# Altium schematic sheet SPI Extender 2.SchDoc
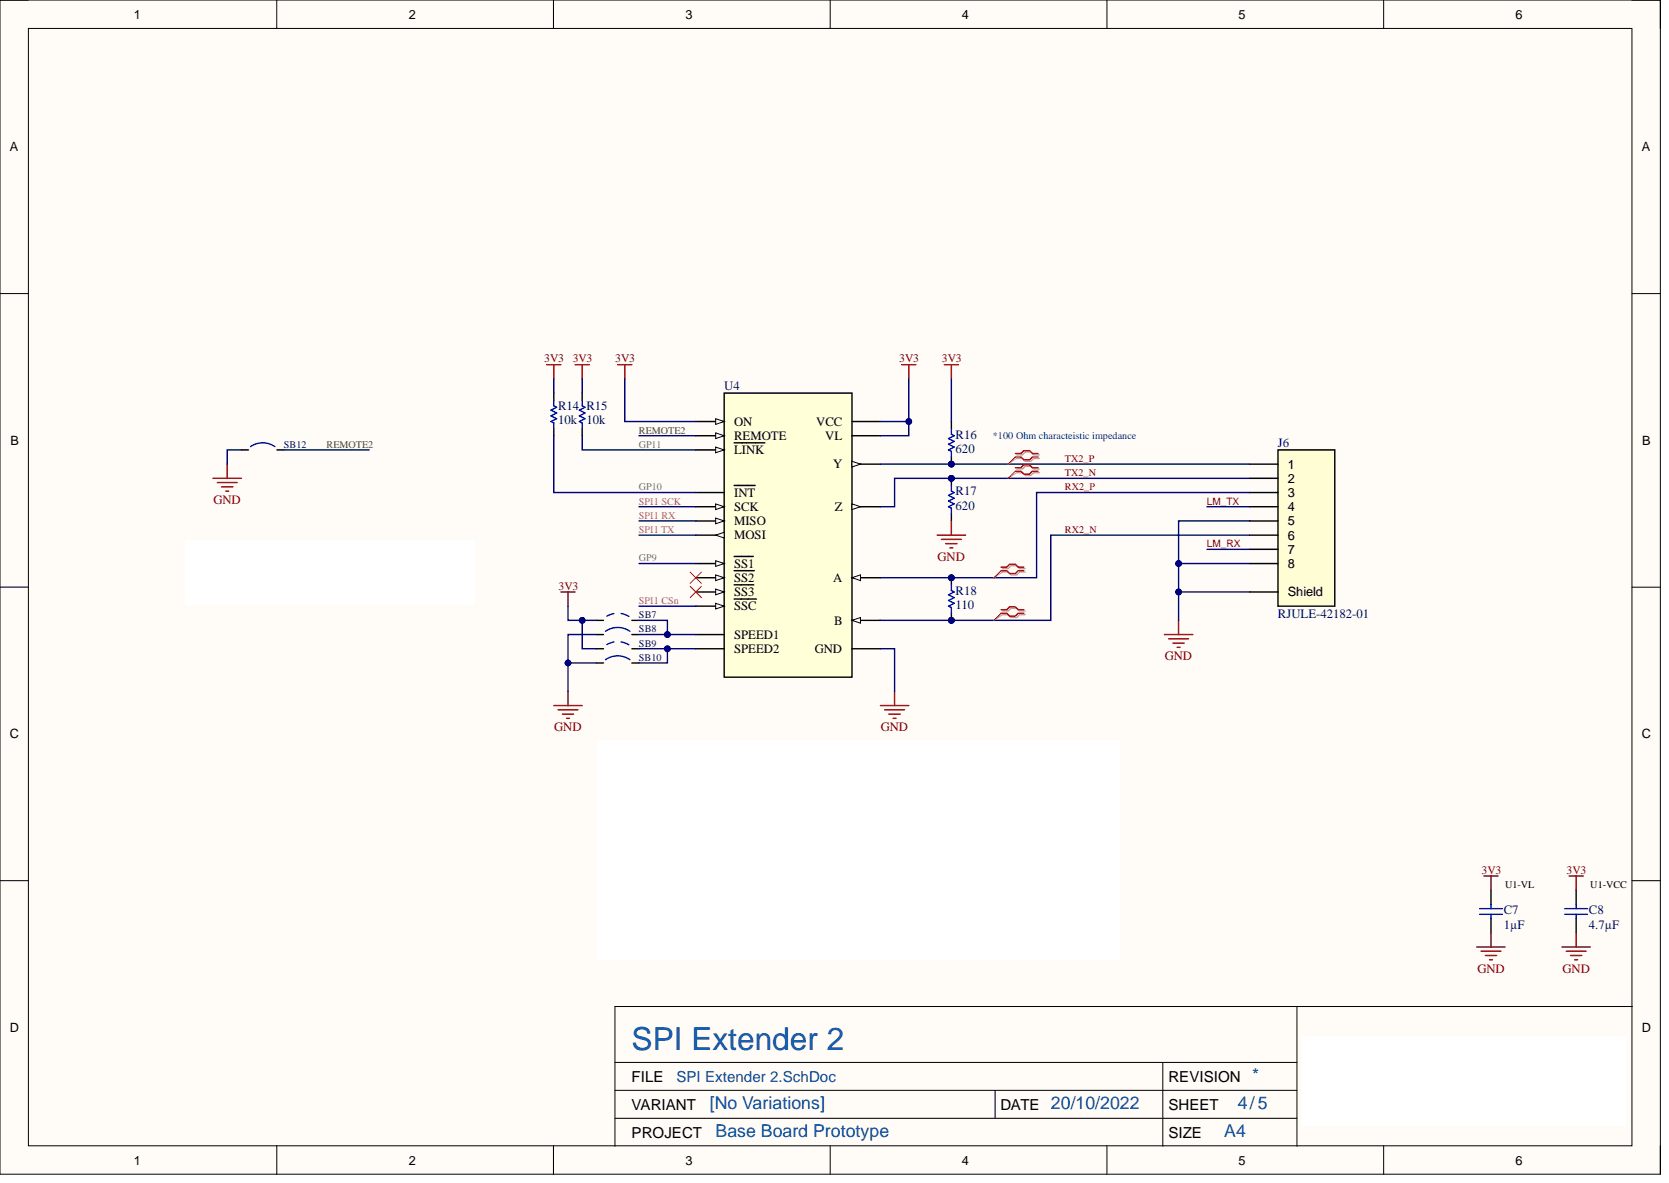
[diagram p0: SPI Extender 2.SchDoc]

## Parts
C7  value 1µF  [FP-GRM188-0_1-e0_2_0_5-IPC_B]  (hidden comment: C0603-1uF-25V-10%-X7R)
C8  value 4.7µF  [CAPC2012X88N]  (hidden comment: C0805-4.7uF-16V-5%-X7R)
J6  RJULE4218201  PartNumber: RJULE-42182-01  [RJULE-42182-01 - RM]
R14  value 10k  Resistance: 10k  [FP-CPF0603-IPC_B]  (hidden comment: R0603-10k-1/16W-0.1%)
R15  value 10k  Resistance: 10k  [FP-CPF0603-IPC_B]  (hidden comment: R0603-10k-1/16W-0.1%)
R16  value 620  [FP-CPF0603-IPC_B]  (hidden comment: R0603-620R-1/16W-0.1%)
R17  value 620  [FP-CPF0603-IPC_B]  (hidden comment: R0603-620R-1/16W-0.1%)
R18  value 110  [FP-CPF0603-IPC_B]  (hidden comment: R0603-110R-1/16W-0.1%)
SB7  Solder Bridge 0603 Off  [Solder Bridge 0603 Off]  (value not drawn)  (no BOM)
SB8  Solder Bridge 0603 On  [Solder Bridge 0603 On]  (value not drawn)
SB9  Solder Bridge 0603 Off  [Solder Bridge 0603 Off]  (value not drawn)  (no BOM)
SB10  Solder Bridge 0603 On  [Solder Bridge 0603 On]  (value not drawn)
SB12  Solder Bridge 0603 On  [Solder Bridge 0603 On]  (value not drawn)
U4  LTC4332HUFD#PBF  [FP-UFD-20-05-08-1711-IPC_B]  (value not drawn)

## Nets
3V3: C7.2 C8.2 R14.2 R15.2 R16.2 SB7.1 SB9.1 U4.1(VCC) U4.2(VL) U4.3(ON)
GND: C7.1 C8.1 J6.5 J6.8 J6.MH(Shield) R17.1 SB8.1 SB10.1 SB12.1 U4.16(GND)
GP9: U4.11(SS1)
GP10: R14.1 U4.10(INT)
GP11: R15.1 U4.5(LINK)
LM_RX: J6.7
LM_TX: J6.4
REMOTE2: SB12.2 U4.4(REMOTE)
RX2_N: J6.6 R18.1 U4.19(B)
RX2_P: J6.3 R18.2 U4.20(A)
SPI1 CSn: U4.6(SSC)
SPI1 RX: U4.9(MISO)
SPI1 SCK: U4.7(SCK)
SPI1 TX: U4.8(MOSI)
TX2_N: J6.2 R17.2 U4.18(Z)
TX2_P: J6.1 R16.1 U4.17(Y)
NetSB7_2: SB7.2 SB8.2 U4.14(SPEED1)
NetSB9_2: SB9.2 SB10.2 U4.15(SPEED2)

Not connected: U4 12(SS2) 13(SS3)

## Library data of the parts
C7 = CMP-611-0033-2: 1µF ±10% 25V Ceramic Capacitor X7R 0603 (1608 Metric); Capacitance: 1uF; Pick&PlaceFootprint: 0603_CAP; Capacitor Type: Ceramic; Case Code (Imperial): 0603; Case Code (Metric): 1608; Case/Package: 0603; Component Kind: Standard; Construction: Flat; Depth: 0.8mm; Dielectric: X7R; Height: 0.9mm; Lead Free: Lead Free; Length: 1.6mm; Material: Ceramic; Max Operating Temperature: 125°C; Min Operating Temperature: -55°C; Mount: Surface Mount; Package Quantity: 1; Packaging: Tape and Reel; Radiation Hardening: No; REACH SVHC: Yes; RoHS: Yes; RoHS Compliant: Yes; Series: GRM; Size: 0.8mm; Termination: Wraparound; Thickness: 0.035inch; Tolerance: 10%; Voltage: 25V; Voltage Rating: 25V; Voltage Rating (DC): 25V; Weight: 0.000222oz; Width: 0.8mm; Library Name: Sainsbury Wellcome Centre
C8 = CMP-611-0019-6: 4.7uF ±5% 16V Ceramic Capacitor X7R 0805 (2012 Metric); Dissipation Factor: 0.025%; Pick&PlaceFootprint: C0805; Capacitance: 4.7uF; Capacitor Type: Ceramic; Case Code (Imperial): 0805; Case Code (Metric): 2012; Case/Package: 0805; Component Kind: Standard; Depth: 1.25mm; Dielectric: X7R; Height: 1.25mm; Lead Free: Lead Free; Length: 2mm; Material: Ceramic; Max Operating Temperature: 125°C; Min Operating Temperature: -55°C; Mount: Surface Mount; Number of Pins: 2; Package Quantity: 1; Packaging: Cut Tape; Radiation Hardening: No; REACH SVHC: No SVHC; RoHS: Yes; Termination: SMD/SMT; Thickness: 0.053inch; Tolerance: 5%; Voltage: 16V; Voltage Rating: 16V; Voltage Rating (DC): 16V; Width: 0.049inch; Library Name: Sainsbury Wellcome Centre
J6 = CMP-300-0001-5: Modular Jack - Right Angle, Input Output Connectors RJ45, 8P8C, Without LEDs, Shield.; Component Kind: Standard; Mount: Surface Mount; Library Name: Sainsbury Wellcome Centre
R14 R15 = CMP-633-0002-15: Surface Mount Resistor 10k Ohm 1/16 W 0.1% 0603 (1608 Metric); Max Power Dissipation: 62.5 mW; Number of Resistors: 1; Pick&PlaceFootprint: R0603; Power Rating: 62.5mW; Temperature Coefficient: 25ppm/°C; Voltage Rating: 50V; Case Code (Imperial): 0603; Case Code (Metric): 1608; Case/Package: 0603; Component Kind: Standard; Composition: Thin Film; ELV: Compliant; Height: 0.45mm; Length: 1.6mm; Max Operating Temperature: 155°C; Min Operating Temperature: -55°C; Mount: Surface Mount; Number of Pins: 2; Number of Terminations: 2; Packaging: Tape and Reel; REACH SVHC: No SVHC; Resistance Tolerance: 0.1%; Resistor Type: Thin Film; RoHS: Yes; RoHS Compliant: Yes; Series: CPF; Size Code: 0603; Technology: Thin Film; Termination: Solder; Tolerance: 0.1%; Voltage Rating (DC): 50V; Weight: 7.1E-05oz; Width: 0.8mm; Library Name: Sainsbury Wellcome Centre
R16 R17 = CMP-633-0079-2: Surface Mount Resistor 620 Ohm 1/16 W 0.1% 0603 (1608 Metric); Max Power Dissipation: 62.5 mW; Number of Resistors: 1; Pick&PlaceFootprint: R0603; Power Rating: 62.5mW; Temperature Coefficient: 25ppm/°C; Voltage Rating: 50V; Case Code (Imperial): 0603; Case Code (Metric): 1608; Case/Package: 0603; Component Kind: Standard; Composition: Thin Film; Construction: Chip; ELV: Compliant; Height: 0.45mm; Length: 1.6mm; Max Operating Temperature: 155°C; Min Operating Temperature: -55°C; Mount: Surface Mount; Number of Pins: 2; Number of Terminations: 2; Packaging: Tape and Reel; REACH SVHC: Yes; Resistance: 620; Resistance Tolerance: 0.1%; Resistor Type: FIXED RESISTOR; RoHS: Yes; RoHS Compliant: Yes; Series: CPF; Size Code: 0603; Technology: THIN FILM; Termination: Wraparound; Tolerance: 0.1%; Voltage Rating (DC): 50V; Weight: 7.1E-05oz; Width: 0.8mm; Library Name: Sainsbury Wellcome Centre
R18 = CMP-633-0080-2: Surface Mount Resistor 110 Ohm 1/16 W 0.1% 0603 (1608 Metric); Max Power Dissipation: 62.5 mW; Number of Resistors: 1; Pick&PlaceFootprint: R0603; Power Rating: 62.5mW; Temperature Coefficient: 25ppm/°C; Voltage Rating: 50V; Case Code (Imperial): 0603; Case Code (Metric): 1608; Case/Package: 0603; Component Kind: Standard; Composition: Thin Film; Construction: Chip; ELV: Compliant; Height: 0.45mm; Length: 1.6mm; Max Operating Temperature: 155°C; Min Operating Temperature: -55°C; Mount: Surface Mount; Number of Pins: 2; Number of Terminations: 2; Packaging: Tape and Reel; REACH SVHC: Yes; Resistance: 110; Resistance Tolerance: 0.1%; Resistor Type: FIXED RESISTOR; RoHS: Yes; RoHS Compliant: Yes; Series: CPF; Size Code: 0603; Technology: THIN FILM; Termination: Wraparound; Tolerance: 0.1%; Voltage Rating (DC): 50V; Weight: 7.1E-05oz; Width: 0.8mm; Library Name: Sainsbury Wellcome Centre
SB7 SB9 = CMP-B00-0001-2: Description: Solder Bridge 0603 OFF; Library Name: Sainsbury Wellcome Centre
SB8 SB10 SB12 = CMP-B00-0000-4: PartNumber: R0603-0R-1/16W-0.1%; Pick&PlaceFootprint: R0603; Case/Package: R0603; Component Kind: Standard; Description: Solder Bridge 0603 ON; Mount: SMD; Pins: 2; Library Name: Sainsbury Wellcome Centre
U4 = CMP-930-0001-1: SPI Extender Over Rugged Differential Link; Pick&PlaceFootprint: QFN-20-4-5; Component Kind: Standard; PartNumber: LTC4332HUFD#PBF; RoHS Compliant: Yes; Library Name: Sainsbury Wellcome Centre
